# Altium schematic sheet JacdacAccelerometer 30.SchDoc
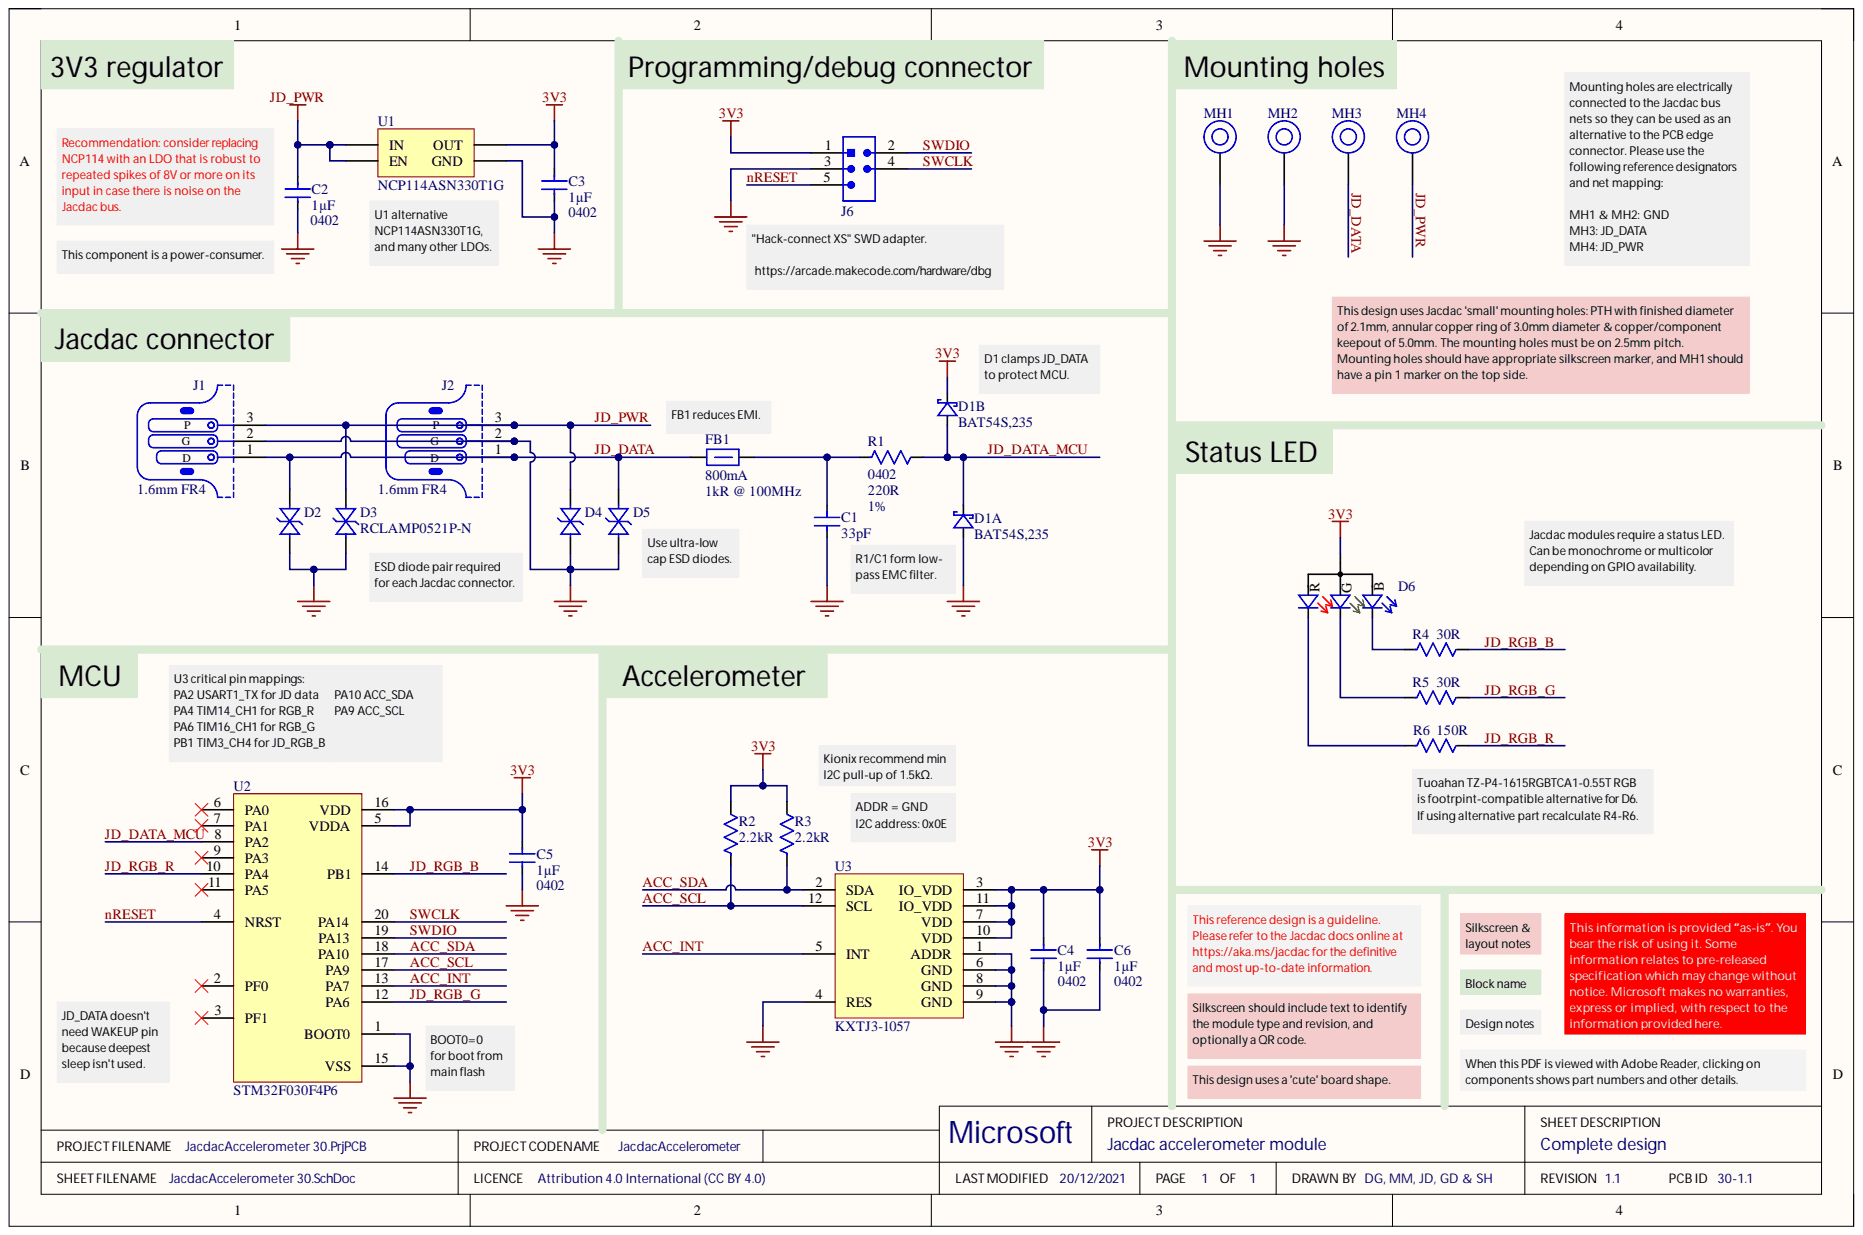
[diagram p0: JacdacAccelerometer 30.SchDoc]

## Parts
C1  Capacitance: 33pF
C2  Capacitance: 1µF  Case Code (Imperial): 0402
C3  Capacitance: 1µF  Case Code (Imperial): 0402
C4  Capacitance: 1µF  Case Code (Imperial): 0402
C5  Capacitance: 1µF  Case Code (Imperial): 0402
C6  Capacitance: 1µF  Case Code (Imperial): 0402
D1  Manufacturer Part Number: BAT54S,235  (parts A,B)
D2  (no value)
D3  Manufacturer Part Number: RCLAMP0521P-N
D4  (no value)
D5  (no value)
D6  (no value)
FB1  Current Rating: 800mA
J1  PCB Type: 1.6mm FR4
J2  PCB Type: 1.6mm FR4
J6  (no value)
MH1  (no value)
MH2  (no value)
MH3  (no value)
MH4  (no value)
R1  Case Code (Imperial): 0402  Resistance: 220R
R2  Resistance: 2.2kR
R3  Resistance: 2.2kR
R4  Resistance: 30R
R5  Resistance: 30R
R6  Resistance: 150R
U1  Manufacturer Part Number: NCP114ASN330T1G
U2  Manufacturer Part Number: STM32F030F4P6
U3  Manufacturer Part Number: KXTJ3-1057

## Nets
3V3: C3.2 C4.2 C5.2 C6.2 D1.2 D6.1 J6.1 R2.1 R3.1 U1.5(OUT) U2.5(VDDA) U2.16(VDD) U3.3(IO_VDD) U3.7(VDD) U3.10(VDD) U3.11(IO_VDD)
GND: C1.1 C2.1 C3.1 C4.1 C5.1 C6.1 D1.1 D2.2 D3.2 D4.2 D5.2 J1.2 J2.2 J6.3 MH1.1 MH2.1 U1.2(GND) U2.1(BOOT0) U2.15(VSS) U3.1(ADDR) U3.4(RES) U3.6(GND) U3.8(GND) U3.9(GND)
ACC_INT: U2.13(PA7) U3.5(INT)
ACC_SCL: R2.2 U2.17(PA9) U3.12(SCL)
ACC_SDA: R3.2 U2.18(PA10) U3.2(SDA)
JD_DATA: D2.1 D5.1 FB1.1 J1.1 J2.1 MH3.1
JD_DATA_MCU: D1.3 R1.1 U2.8(PA2)
JD_PWR: C2.2 D3.1 D4.1 J1.3 J2.3 MH4.1 U1.1(IN) U1.3(EN)
JD_RGB_B: R4.2 U2.14(PB1)
JD_RGB_G: R5.2 U2.12(PA6)
JD_RGB_R: R6.2 U2.10(PA4)
nRESET: J6.5 U2.4(NRST)
SWCLK: J6.4 U2.20(PA14)
SWDIO: J6.2 U2.19(PA13)
NetC1_2: C1.2 FB1.2 R1.2
NetD6_2: D6.2 R6.1
NetD6_3: D6.3 R5.1
NetD6_4: D6.4 R4.1

Not connected: U2 2(PF0) 3(PF1) 6(PA0) 7(PA1) 9(PA3) 11(PA5)

## Library data of the parts
C1 = (no symbol name): CAP CER 33PF 50V NP0 0402; Manufacturer: Samsung; Manufacturer Part Number: CL05C330JB51PNC; Tolerance: 5%; Case Code (Imperial): 0402; Case Code (Metric): 1005; Case/Package: 0402; Category: Capacitors; ComponentLink1Description: Samsung datasheet online; ComponentLink1URL: http://www.samsungsem.com/kr/support/library/product-catalog/mlcc.jsp; Max Operating Temperature: 125°C; Min Operating Temperature: -55°C; Ratings: AEC-Q200; Supplier 1: Digi-Key; Supplier 2: Mouser; Supplier 3: Future Electronics; Supplier Part Number 1: 1276-6515-1-ND; Supplier Part Number 2: 187-CL05C330JB51PNC; Supplier Part Number 3: 6022520; Voltage Rating: 50V
C2-C6 = (no symbol name): CAP CER 1UF 10V X5R 0402; Manufacturer: Samsung; Manufacturer Part Number: CL05A105KP5NNNC; Material: Ceramic; Tolerance: 10%; Case Code (Metric): 1005; Case/Package: 0402; Category: Capacitors; ComponentLink1Description: Online datasheet; ComponentLink1URL: https://datasheet.ciiva.com/15195/getdatasheetpartid-358390-15195630.pdf; Contact Plating: Tin; Dielectric: X5R; Max Operating Temperature: 85°C; Min Operating Temperature: -55°C; Supplier 1: Digi-Key; Supplier 2: Mouser; Supplier 3: Farnell; Supplier Part Number 1: 1276-1076-1-ND; Supplier Part Number 2: 187-CL05A105KP5NNNC; Supplier Part Number 3: 3013329; Voltage Rating: 10V
D1 = (no symbol name): DIODE ARRAY SCHOTTKY 30V SOT23; Manufacturer: Nexperia; Category: Rectifier Diodes; ComponentLink1Description: NXP datasheet online; ComponentLink1URL: https://assets.nexperia.com/documents/data-sheet/BAT54_SER.pdf; Supplier 1: Digi-Key; Supplier 2: Mouser; Supplier 3: RSComponents; Supplier Part Number 1: 1727-1868-1-ND; Supplier Part Number 2: 771-BAT54S235; Supplier Part Number 3: 1707990
D2 D4 D5 = (no symbol name): Bidirectional 5V (Max) 6V 16V 3.5A (8/20us) 0402 TVS RoHS; Manufacturer: Born Semiconductor; Manufacturer Part Number: RCLAMP0521P-N; ComponentLink1Description: Online datasheet; ComponentLink1URL: https://datasheet.lcsc.com/szlcsc/Bourne-Semicon-Shenzhen-RCLAMP0521P-N_C316043.pdf; Package: 0402; Supplier1: LCSC; Supplier1 Part Number: C316043
D3 = (no symbol name): Bidirectional 5V (Max) 6V 16V 3.5A (8/20us) 0402 TVS RoHS; Manufacturer: Born Semiconductor; ComponentLink1Description: Online datasheet; ComponentLink1URL: https://datasheet.lcsc.com/szlcsc/Bourne-Semicon-Shenzhen-RCLAMP0521P-N_C316043.pdf; Package: 0402; Supplier1: LCSC; Supplier1 Part Number: C316043
D6 = (no symbol name): Red, Green, Blue (RGB) 626nm Red, 525nm Green, 470nm Blue LED Indication - Discrete 1.9V Red, 3.4V Green, 3.4V Blue 0606 (1616 Metric); Manufacturer: Broadcom Avago; Manufacturer Part Number: HSMF-C114; Color: Blue,Green,Red; Forward Current: 20mA; Lens Color: White; Lens Style: Diffused,Rectangular; Lens Transparency: Diffused; Luminous Intensity: 70mcd; Number of Elements: 3; Power Rating: 78mW; Reverse Voltage: 5V; Viewing Angle: 145°; Case Code (Imperial): 0606; Case Code (Metric): 1616; Case/Package: 0606; Category: Optoelectronics; ComponentLink1Description: Online datasheet; ComponentLink1URL: https://docs.broadcom.com/docs/AV02-2451EN; Dominant Wavelength: 470nm; Forward Voltage: 3.4V; Max Operating Temperature: 85°C; Min Operating Temperature: -40°C; Number of LEDs: 3; Number of Pins: 4; Supplier 1: Digi-Key; Supplier 2: Farnell; Supplier 3: Mouser; Supplier Part Number 1: 516-1795-1-ND; Supplier Part Number 2: 1058362; Supplier Part Number 3: 630-HSMF-C114; Test Current: 20mA; Wavelength: 470nm
FB1 = (no symbol name): 0603 1k@100MHz 0.8A chip; Manufacturer: TDK; Manufacturer Part Number: MPZ1608S102ATA00; Core Material: Ferrite; DC Resistance (DCR): 300mR; Impedance: 1kR @ 100MHz; Tolerance: 25%; Case Code (Imperial): 0603; Case Code (Metric): 1608; Case/Package: 0603; ComponentLink2Description: datasheet online; ComponentLink2URL: https://www.mouser.co.uk/datasheet/2/400/eads_commercial_power_mpz1608_en-923650.pdf; ComponentLink3Description: datasheet; Max DC Current: 800mA; Supplier 1: Digi-Key; Supplier 2: Mouser; Supplier Part Number 1: 445-8694-1-ND; Supplier Part Number 2: 810-MPZ1608S102ATA00; ComponentLink3URL: Y:\System\Altium\Libraries 2014\TDK\Datasheets\eads_commercial_power_mpz1608_en-923650.pdf
J1 J2 = (no symbol name): 3 pin Jacdac edge connector 1.27mm pitch; Manufacturer Part Number: JACDAC_EDGE_CONNECTOR; ComponentLink1Description: Yuliang JACDAC cable connector drawing online; ComponentLink1URL: https://github.com/microsoft/jacdac-mdk/blob/main/connector/JACDAC-Connector-by-Yuliang-2020-11-28.pdf; ComponentLink2Description: JACDAC PCB edge connector spec onlin; ComponentLink2URL: https://github.com/microsoft/jacdac-mdk/blob/main/connector/JACDAC_PCB_Edge_Connector_Drawing_JD-PEC-01_rev_01.pdf
J6 = (no symbol name): Hack Connect Mini 1.27 mm pitch 2-row
MH1-MH4 = (no symbol name): Mounting Hole 5.0mm keepout, 3.0mm annualar ring and 2.1mm Drill PTH
R1 = (no symbol name): RES SMD 220 OHM 1% 1/16W 0402; Manufacturer: Yageo; Manufacturer Part Number: RC0402FR-07220RL; Max Power Dissipation: 63mW; Power Rating: 62.5mW; Temperature Coefficient: 100ppm/°C; Voltage Rating: 50V; Case Code (Metric): 1005; Case/Package: 0402; Category: Resistors; ComponentLink1Description: Online datasheet; ComponentLink1URL: https://datasheet.ciiva.com/11819/0900766b80c66297-11819466.pdf; Features: Moisture Resistant; Max Operating Temperature: 155°C; Min Operating Temperature: -55°C; Number of Pins: 2; Number of Terminations: 2; Resistor Type: Thick Film; Supplier 1: Digi-Key; Supplier 2: Mouser; Supplier 3: Farnell; Supplier Part Number 1: 311-220LRCT-ND; Supplier Part Number 2: 603-RC0402FR-07220RL; Supplier Part Number 3: 2147864; Termination: SMD/SMT; Tolerance: 1%; Voltage Rating (DC): 50V
R2 R3 = (no symbol name): RES SMD 2.2K OHM 1% 1/16W 0402; Manufacturer: Yageo; Manufacturer Part Number: RC0402FR-072K2L; Power Rating: 63mW; Temperature Coefficient: 100ppm/°C; Voltage Rating: 50V; Case Code (Imperial): 0402; Case Code (Metric): 1005; Case/Package: 0402; Category: Resistors; ComponentLink1Description: Online datasheet; ComponentLink1URL: https://datasheet.ciiva.com/11808/0900766b80c66297-11808786.pdf; Max Operating Temperature: 155°C; Min Operating Temperature: -55°C; Resistor Type: Thick Film; Supplier 1: Digi-Key; Supplier 2: Mouser; Supplier 3: Farnell; Supplier Part Number 1: 311-2.20KLRCT-ND; Supplier Part Number 2: 603-RC0402FR-072K2L; Supplier Part Number 3: 9239278; Termination: SMD/SMT; Tolerance: 1%; Weight: 2.3E-05oz; by: ?
R4 R5 = (no symbol name): RES SMD 30 OHM 1% 1/16W 0402; Manufacturer: Yageo; Manufacturer Part Number: RC0402FR-0730RL; Max Power Dissipation: 63mW; Temperature Coefficient: 100ppm/°C; Voltage Rating: 50V; Case Code (Imperial): 0402; Case Code (Metric): 1005; Case/Package: 0402; Category: Chip SMD Resistors; ComponentLink1Description: Online datasheet; ComponentLink1URL: https://datasheet.ciiva.com/7849/1018594-7849958.pdf; Construction: Rectangular; Contact Plating: Tin,Matte; Features: Moisture Resistant; Max Operating Temperature: 155°C; Min Operating Temperature: -55°C; Number of Terminations: 2; Power Rating: 63mW; Resistance Tolerance: 1%; Resistor Type: Thick Film; RoHS Compliant: Yes; Series: RC; Size Code: 0402; Supplier 1: Digi-Key; Supplier 2: Mouser; Supplier 3: Farnell; Supplier Part Number 1: 311-30LRCT-ND; Supplier Part Number 2: 603-RC0402FR-0730RL; Supplier Part Number 3: 1458775; Termination: SMD/SMT; Tolerance: 1%; Voltage Rating (DC): 50V
R6 = (no symbol name): RES SMD 150 OHM 1% 1/16W 0402; Manufacturer: Yageo; Manufacturer Part Number: RC0402FR-07150RL; Max Power Dissipation: 63mW; Power Rating: 62.5mW; Temperature Coefficient: 100ppm/°C; Voltage Rating: 50V; Case Code (Imperial): 0402; Case Code (Metric): 1005; Case/Package: 0402; Category: Chip SMD Resistors; ComponentLink1Description: Online datasheet; ComponentLink1URL: https://datasheet.ciiva.com/11808/0900766b80c66297-11808422.pdf; Construction: Rectangular; Features: Moisture Resistant; Max Operating Temperature: 155°C; Min Operating Temperature: -55°C; Number of Terminations: 2; Resistance Tolerance: 1%; Resistor Type: Thick Film; RoHS Compliant: Yes; Series: RC; Supplier 1: Digi-Key; Supplier 2: Mouser; Supplier 3: Farnell; Supplier Part Number 1: 311-150LRCT-ND; Supplier Part Number 2: 603-RC0402FR-07150RL; Supplier Part Number 3: 2421893; Technology: THICK FILM; Termination: SMD/SMT; Tolerance: 1%; Working Voltage: 50V
U1 = (no symbol name): ON SEMICONDUCTOR - NCP114ASN330T1G - LDO Voltage Regulator, Fixed, 1.7 V to 5.5 V in, 3.3 V/300 mA out, 150 mV drop, TSOP-5; Dropout Voltage: 225mV; Max Input Voltage: 5.5V; Min Output Voltage: 3.3V; Number of Regulators: 1; Output Configuration: Positive; Output Current: 300mA; Power Supply Rejection Ratio (PSRR): 75dB; Quiescent Current: 95uA; Case/Package: SOT-23-5; Category: Voltage Regulators - Linear; ComponentLink3Description: On Semi datasheet online; ComponentLink3URL: http://www.onsemi.com/pub/Collateral/NCP114-D.PDF; Manufacturer: ON Semiconductor; Max Operating Temperature: 85°C; Min Operating Temperature: -40°C; Output Type: Fixed; Supplier 1: Digi-Key; Supplier 2: RSComponents; Supplier 3: Mouser; Supplier Part Number 1: NCP114ASN330T1GOSCT-ND; Supplier Part Number 2: 1263178; Supplier Part Number 3: 863-NCP114ASN330T1G
U2 = (no symbol name): ARM Cortex-M0 STM32F0 Microcontroller IC 32-Bit 48MHz 16KB (16K x 8) FLASH; Manufacturer: STMicroelectronics; Categories: Integrated Circuits; Data Bus Width: 32b; Interface: I2C,SPI,UART,USART; Max Power Dissipation: 182mW; Max Supply Voltage: 3.6V; Min Supply Voltage: 2.4V; Number of ADC Channels: 16; Number of I2C Channels: 1; Number of I/Os: 15; Number of PWM Channels: 6; Number of Timers/Counters: 5; Oscillator Type: Internal; Peripherals: POR,PWM,WDT,DMA; RAM Size: 4KB; Watchdog Timer: Yes; Case/Package: TSSOP; Category: Microcontrollers; ComponentLink1Description: Online datasheet; ComponentLink1URL: https://datasheet.ciiva.com/29720/dm00088500-29720640.pdf; Contact Plating: Gold; Core Architecture: ARM; Frequency: 48MHz; Max Frequency: 48MHz; Max Operating Temperature: 85°C; Memory Size: 16KB; Memory Type: FLASH; Min Operating Temperature: -40°C; Number of Pins: 20; Number of Programmable I/O: 15; Number of SPI Channels: 1; Supplier 1: Farnell; Supplier 2: Digi-Key; Supplier 3: RSComponents; Supplier Part Number 1: 2393635; Supplier Part Number 2: 497-14044-5-ND; Supplier Part Number 3: 7925925P
U3 = (no symbol name): KIONIX - KXTJ3-1057 - MEMS ACCELEROMETER, 2G/4G/8G, LGA-12; Manufacturer: Rohm Kionix; Axis: X, Y, Z; Bandwidth: 800Hz; Output Type: I2C; Case/Package: LGA; ComponentLink2Description: Online datasheet; ComponentLink2URL: http://kionixfs.kionix.com/en/datasheet/KXTJ3-1057-Specifications-Rev-4.0.pdf; Max Operating Temperature: 85°C; Min Operating Temperature: -40°C; Number of Pins: 12; Supplier 1: Digi-Key; Supplier 2: Mouser; Supplier Part Number 1: KXTJ3-1057CT-ND; Supplier Part Number 2: 912-KXTJ3-1057
